# Altium schematic sheet IMU.SchDoc(IMU)
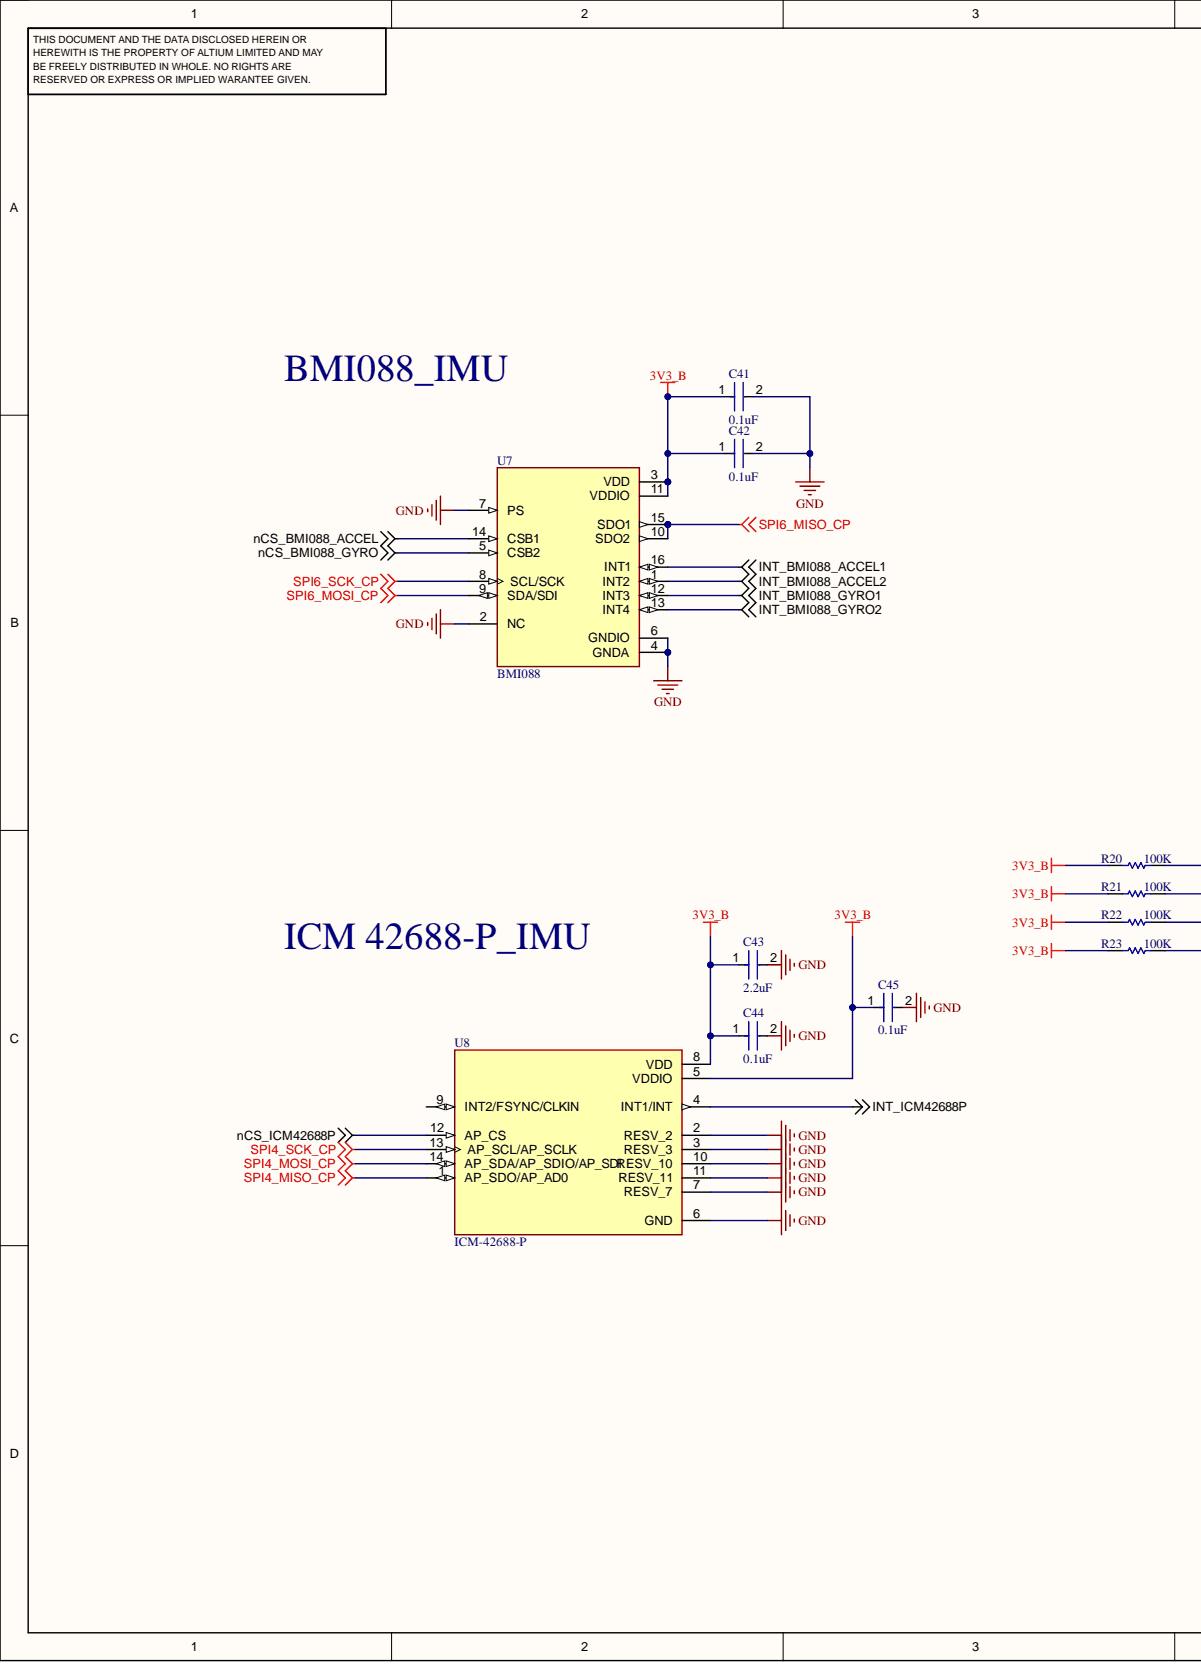
[diagram p0: IMU.SchDoc, left]
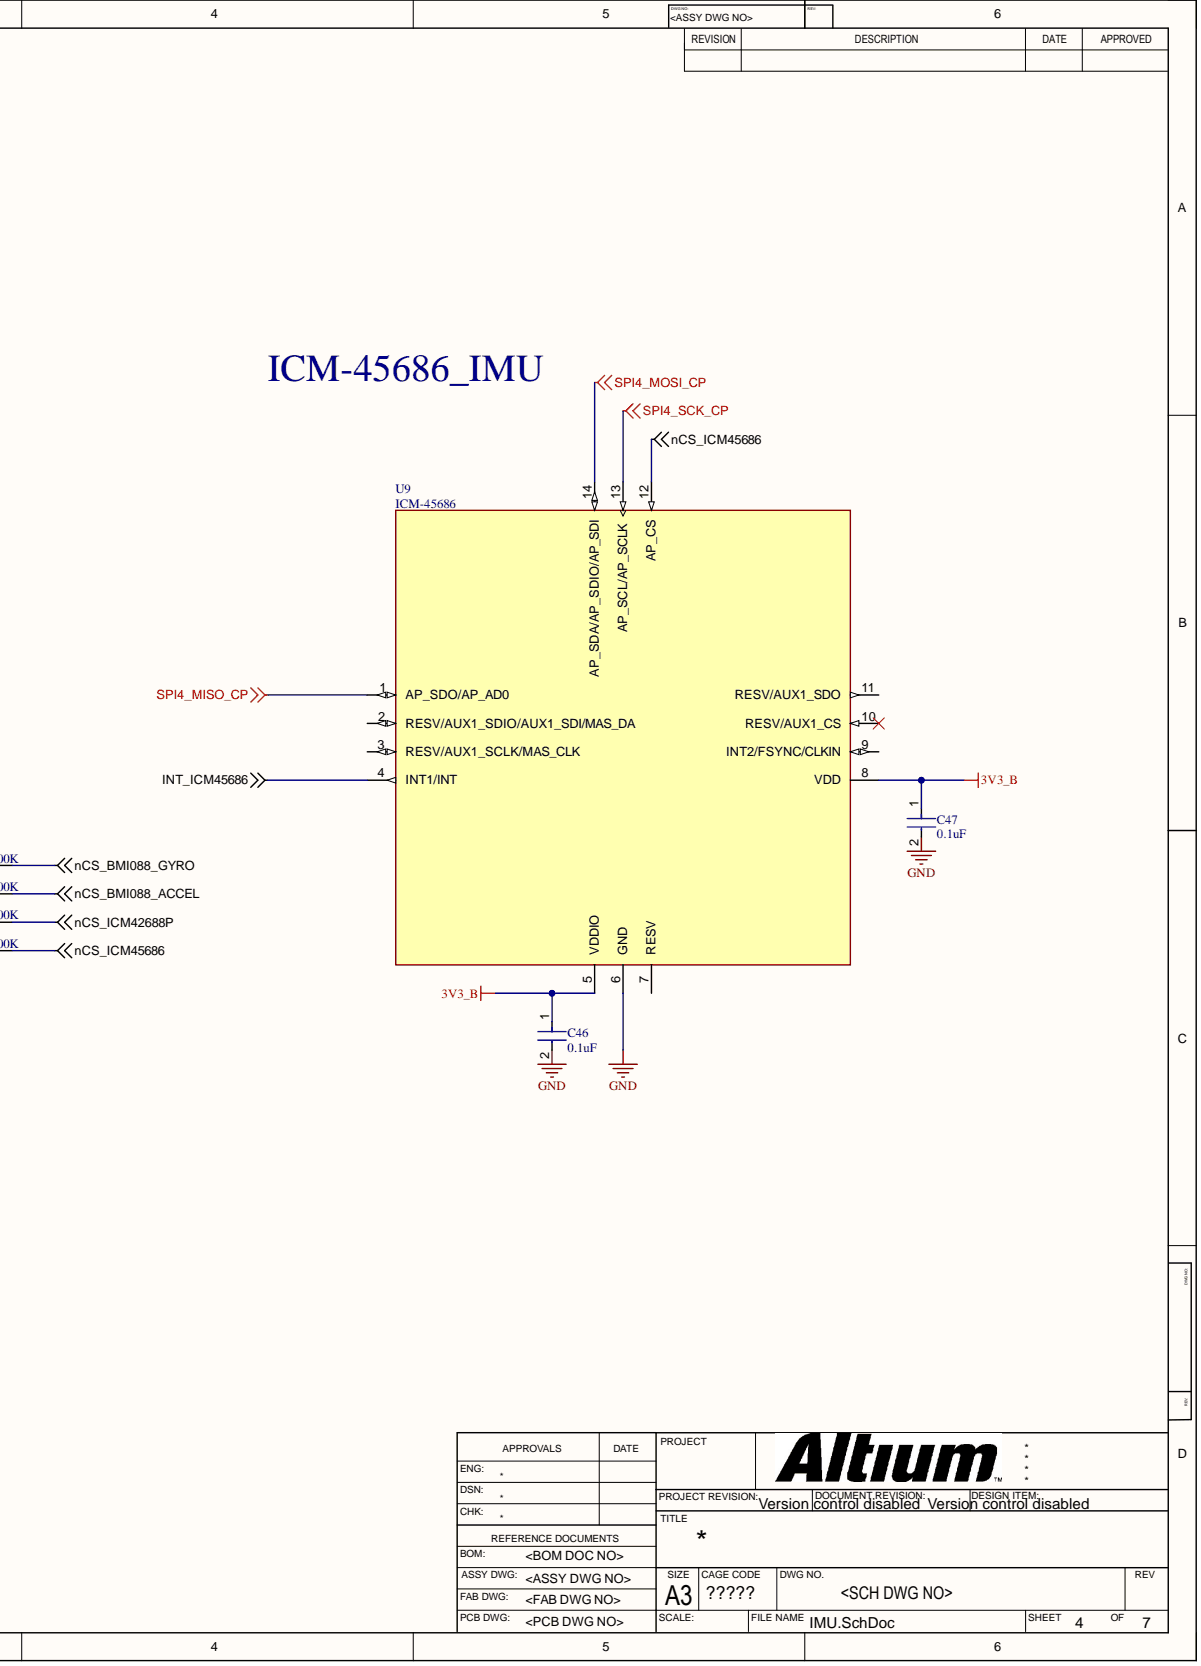
[diagram p1: IMU.SchDoc, right]

## Parts
C41  0.1uF
C42  0.1uF
C43  2.2uF
C44  0.1uF
C45  0.1uF
C46  0.1uF
C47  0.1uF
R20  100K
R21  100K
R22  100K
R23  100K
U7  BMI088
U8  ICM-42688-P
U9  ICM-45686

## Nets
3V3_B: C41.1 C42.1 C43.1 C44.1 C45.1 C46.1 C47.1 R20.2 R21.2 R22.2 R23.2 U7.3(VDD) U7.11(VDDIO) U8.5(VDDIO) U8.8(VDD) U9.5(VDDIO) U9.8(VDD)
GND: C41.2 C42.2 C43.2 C44.2 C45.2 C46.2 C47.2 U7.2(NC) U7.4(GNDA) U7.6(GNDIO) U7.7(PS) U8.2(RESV_2) U8.3(RESV_3) U8.6(GND) U8.7(RESV_7) U8.10(RESV_10) U8.11(RESV_11) U9.6(GND)
INT_BMI088_ACCEL1: U7.16(INT1)
INT_BMI088_ACCEL2: U7.1(INT2)
INT_BMI088_GYRO1: U7.12(INT3)
INT_BMI088_GYRO2: U7.13(INT4)
INT_ICM42688P: U8.4(INT1/INT)
INT_ICM45686: U9.4(INT1/INT)
nCS_BMI088_ACCEL: R21.1 U7.14(CSB1)
nCS_BMI088_GYRO: R20.1 U7.5(CSB2)
nCS_ICM42688P: R22.1 U8.12(AP_CS)
nCS_ICM45686: R23.1 U9.12(AP_CS)
SPI4_MISO_CP: U8.1(AP_SDO/AP_AD0) U9.1(AP_SDO/AP_AD0)
SPI4_MOSI_CP: U8.14(AP_SDA/AP_SDIO/AP_SDI) U9.14(AP_SDA/AP_SDIO/AP_SDI)
SPI4_SCK_CP: U8.13(AP_SCL/AP_SCLK) U9.13(AP_SCL/AP_SCLK)
SPI6_MISO_CP: U7.10(SDO2) U7.15(SDO1)
SPI6_MOSI_CP: U7.9(SDA/SDI)
SPI6_SCK_CP: U7.8(SCL/SCK)

Not connected: U8 9(INT2/FSYNC/CLKIN); U9 2(RESV/AUX1_SDIO/AUX1_SDI/MAS_DA) 3(RESV/AUX1_SCLK/MAS_CLK) 7(RESV) 9(INT2/FSYNC/CLKIN) 10(RESV/AUX1_CS) 11(RESV/AUX1_SDO)

## Library data of the parts
C41 C42 C44-C47 = CMP-2006-03061-2: CAP CER 0.1UF 50V X7R 0603; Manufacturer: KEMET; Manufacturer Part Number: C0603C104K5RACTU; Automotive Grade: Grade 1; Capacitance: 0.10 μF; Material: Ceramic; Temperature Coefficient: 30ppm/°C; Tolerance: 0.1; Value: 0.10 μF; Automotive: Yes; Category: Cap; Component Kind: Standard; ComponentLink2Description: Datasheet; ComponentLink2URL: https://api.kemet.com/component-edge/download/datasheet/C0603C100J5GACAUTO.pdf; Device Class L1: Passive Components; Device Class L2: Capacitors; Device Class L3: Ceramic Capacitors; Digikey Description: CAP CER 0.1UF 50V X7R 0603; Height: 0.87mm; Lead Free: Yes; Library Name: Altium Content Vault; Octopart: https://octopart.com/c0603c104k5ractu-kemet-139244; Package: C0603C-CF; RoHS: TRUE; Temperature: -55°C to +125°C; Temperature Characteristic: X7R; Temperature Range High: +125°C; Temperature Range Low: -55°C; Voltage Rating: 50 V
C43 = CMP-2006-02836-2: Chip Multilayer Ceramic Capacitors for General Purpose, 0603, 2.2uF, X7R, 15%, 10%, 10V; Capacitance: 2.2uF; Case Code (Imperial): 0603; Case Code (Metric): 1608; Case/Package: 0603; Component Kind: Standard; Depth: 0.8mm; Dielectric: X7R; Height: 0.8mm; Lead Free: Lead Free; Length: 1.6mm; Library Name: Altium Content Vault; Material: Ceramic; Max Operating Temperature: 125°C; Min Operating Temperature: -55°C; Mount: Surface Mount; Number of Pins: 2; Package Quantity: 1; Packaging: Cut Tape; REACH SVHC: No SVHC; Termination: SMD/SMT; Thickness: 0.035inch; Tolerance: 10%; Voltage: 10V; Voltage Rating: 10V; Voltage Rating (DC): 10V; Weight: 7.1E-05oz; Width: 0.031inch
R20-R23 = CMP-2000-01852-1: Manufacturer: KOA Speer; Part Number: RK73B1JTTD104J; Component Kind: Standard; Library Name: Altium Content Vault
U7 = CMP-010-00024-2: High-performance 6-axis inertial sensor (accelerometer + gyroscope) <a href="https://pricing.snapeda.com/parts/BMI088/Bosch%20Sensortec/view-part?ref=eda">Check prices</a>; Availability: Unavailable; Case/Package: None; Component Kind: Standard; Component Type: Standard; Design Item ID: BMI088; MP: BMI088; Pins: 16; Price: None; Source: BMI088.SchLib; Library Name: Hitesh Bhoyar
U8 = CMP-010-00026-2: Accelerometer, Gyroscope, 6 Axis Sensor Output; Availability: Unavailable; Case/Package: None; Component Kind: Standard; Component Type: Standard; Design Item ID: ICM-42688-P; MP: ICM-42688-P; Pins: 14; Price: None; Source: ICM-42688-P.SchLib; Library Name: Hitesh Bhoyar
U9 = CMP-010-00025-1: Accelerometer, Gyroscope, 6 Axis Sensor I2C, I3C, SPI Output; MF: TDK InvenSense; Case/Package: Package; Check_prices: https://www.snapeda.com/parts/ICM-45686/TDK+InvenSense/view-part/?ref=eda; Component Kind: Standard; Component Type: Standard; Design Item ID: ICM-45686; MP: ICM-45686; Pins: 14; SnapEDA_Link: https://www.snapeda.com/parts/ICM-45686/TDK+InvenSense/view-part/?ref=snap; Source: ICM-45686.SchLib; Library Name: Hitesh Bhoyar
